# Altium schematic sheet inputbout.SchDoc
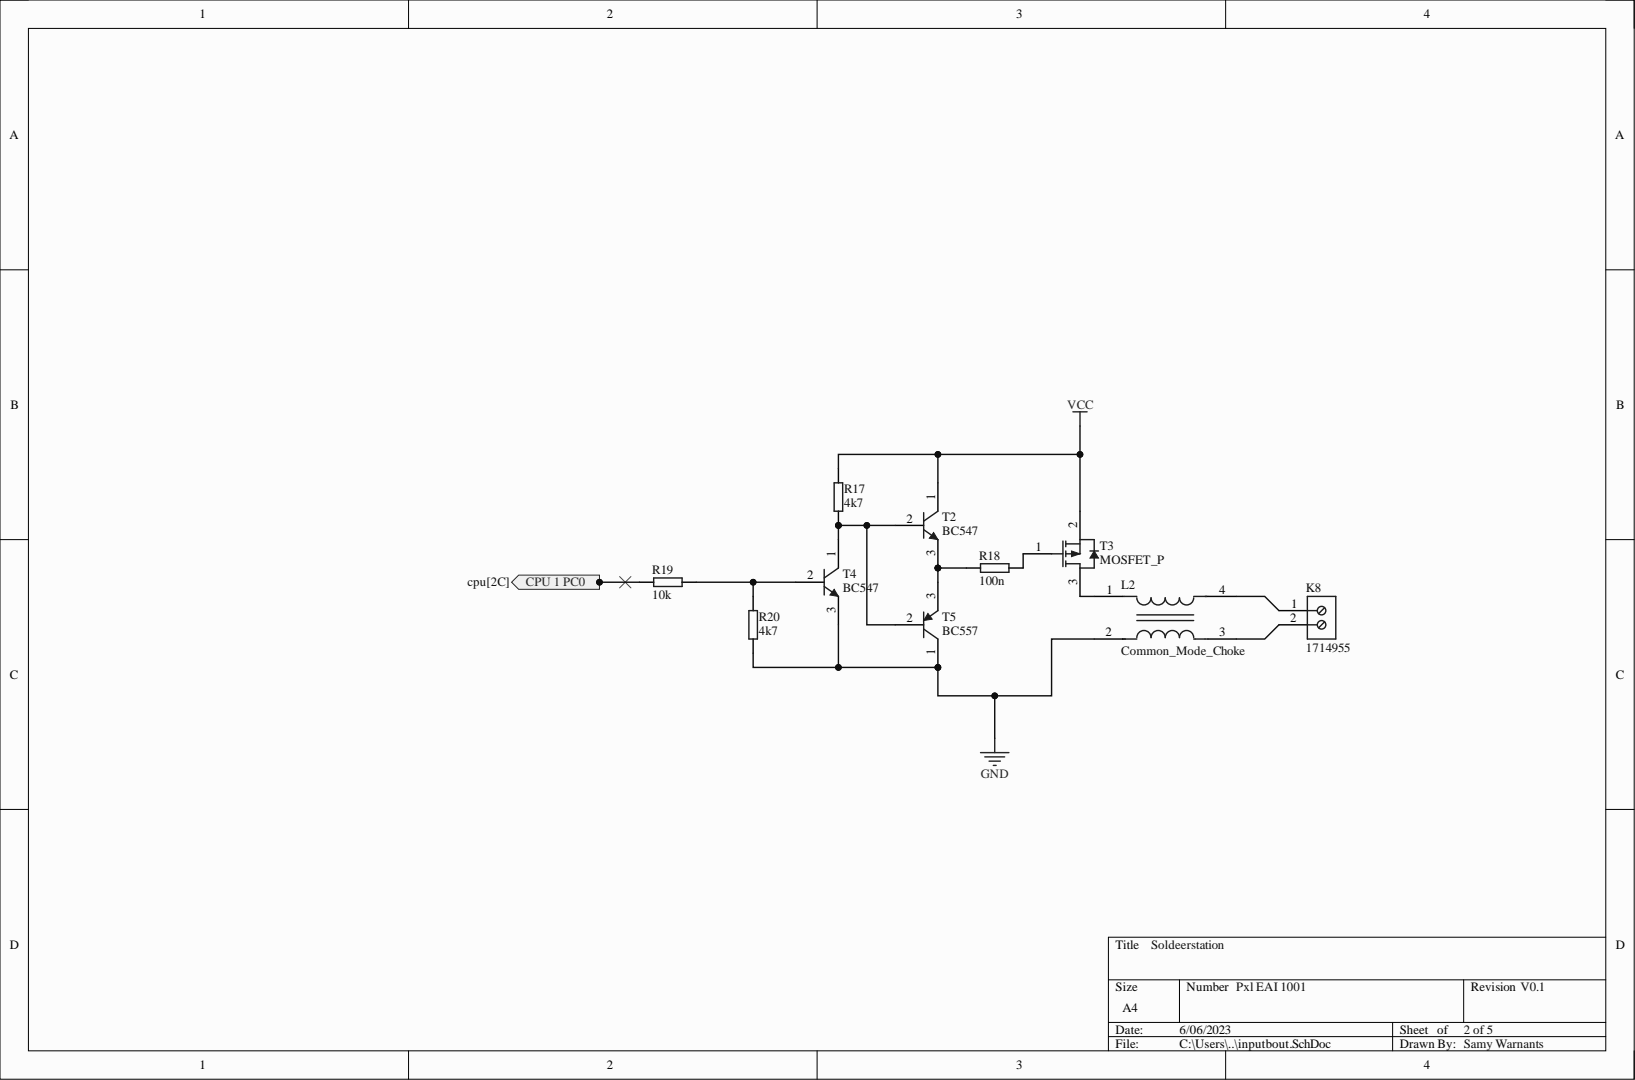
[diagram p0: inputbout.SchDoc]

## Parts
K8  1714955  [PHOE-1714955_V]
L2  Common_Mode_Choke  [2545]
R17  4k7  [R_0805_M]
R18  100n  [R_0805_M]
R19  10k  [R_0805_M]
R20  4k7  [R_0805_M]
T2  BC547  [SOT_23]
T3  MOSFET_P  [TO_252]
T4  BC547  [SOT_23]
T5  BC557  [SOT_23]

## Ports
CPU 1 PC0

## Nets
GND: L2.2 R20.1 T4.3 T5.1
VCC: R17.2 T2.1 T3.2
CPU 1 PC0: R19.2 ; port CPU 1 PC0
NetK8_1: K8.1 L2.4
NetK8_2: K8.2 L2.3
NetL2_1: L2.1 T3.3
NetR17_1: R17.1 T2.2 T4.1 T5.2
NetR18_1: R18.1 T3.1
NetR18_2: R18.2 T2.3 T5.3
NetR19_1: R19.1 R20.2 T4.2

## Library data of the parts
K8 = CMP-2000-05797-1: PC Terminal Block, Pitch 6.35 mm, 1 x 2 Position, Height 21.5 mm, Tail Lenght 5.1 mm, RoHS, Bulk; Manufacturer: Phoenix Contact; Component Kind: Standard; Datasheet URL: http://media.digikey.com/pdf/Data%20Sheets/Phoenix%20Contact%20PDFs/1714955.pdf; Datasheet Version: 02/2010; Library Name: Altium Content Vault; Manufacturer URL: http://www.phoenixcontact.com/; Mounting Technology: ThroughHole; Package Description: PC Terminal Block, Pitch 6.35 mm, 1 x 2 Position, Height 21.5 mm, Tail Lenght 5.1 mm; Package Reference: 1714955
L2 = Common_Mode_Choke: Current: 2A; Current Rating: 2.3A; DC Resistance (DCR): 60mR; Element Configuration: Dual; Impedance: 900R; Insulation Resistance: 10MR; Max Voltage Rating (DC): 50V; Number of Lines: 2; Test Frequency: 100MHz; Case/Package: 1808; Component Kind: Standard; DC Current: 2A; DC Resistance-Max: 60mR; Depth: 4.7mm; Height: 2mm; Lead Free: Lead Free; Length: 0.185inch; Max Current Rating: 2A; Max DC Current: 2A; Max Operating Temperature: 85°C; Min Operating Temperature: -40°C; Mount: Surface Mount; Number of Pins: 4; Package Quantity: 1; Packaging: Tape and Reel; Radiation Hardening: No; REACH SVHC: No SVHC; RoHS Compliant: Yes; Series: ACM; Termination: Flat; Voltage Rating: 50V; Voltage Rating (DC): 50V; Width: 4.5mm; Library Name: Soldeerstation_Final (1).SchLib
R17-R20 = Resistor: Manufacturer: Vishay Dale; Part Number: CRCW08050000Z0EA; Component Kind: Standard; Library Name: Soldeerstation_Final (1).SchLib
T2 T4 = Transistor_NPN: Manufacturer: On Semiconductor; PartNumber: BC547C; fT Min (MHz): 150; IC Continuous (A): 0.1; Packing: Bulk Box; Polarity: NPN; Component Kind: Standard; ComponentLink1Description: Manufacturer URL; ComponentLink1URL: http://www.onsemi.com/; ComponentLink2Description: Datasheet; ComponentLink2URL: http://www.onsemi.com/pub_link/Collateral/BC546-D.PDF; ComponentLink3Description: Package Specification; ComponentLink3URL: http://www.onsemi.cn/pub_link/Collateral/2N6426-D.PDF; DatasheetVersion: Rev. 7; Mounting Technology: Through Hole; PackageDescription: 3-Pin Transistor Outline, Body 4.825 x 3.685 mm, Pitch 1.27 mm; PackageReference: TO-92-3-29-11_AM_SL; PackageVersion: Rev. AM; RoHS: FALSE; Library Name: Soldeerstation_Final (1).SchLib
T3 = MOSFET_P: Manufacturer: Fairchild Semiconductor; Continuous Drain Current Id: 2.4A; Current Temperature: 25°C; Drain Source Voltage Vds: 20V; External Depth: 2.5mm; External Length / Height: 1.12mm; External Width: 3.05mm; No. of Pins: 3; On Resistance Rds(on): 52mohm; Package Version: Rev. 3, 05/2015; Power Dissipation Pd: 500mW; Pulse Current Idm: 10A; Rds(on) Test Voltage Vgs: -4.5V; Reel Quantity: 3000; SMD Marking: 304P; SVHC: NoSVHC(19-Dec-2012); Threshold Voltage Vgs Typ: -800mV; Transistor Case Style: SOT-23; Transistor Polarity: PChannel; Voltage Vds Typ: -20V; Voltage Vgs th Max: -1.5V; Component Kind: Standard; Current Id Max: 2.4A; Datasheet URL: https://www.fairchildsemi.com/datasheets/FD/FDN304P.pdf; Datasheet Version: Rev. C, 01/2001; Full Power Rating Temperature: 25°C; Manufacturer URL: https://www.fairchildsemi.com/; Mounting Technology: SurfaceMount; Operating Temperature Max: 150°C; Operating Temperature Min: -55°C; Operating Temperature Range: -55°Cto+150°C; Package / Case: SOT-23; Package Description: 3-Pin Small Outline Transistor, Body 2.92 x 1.4 mm, Pitch 0.95 mm; Package Reference: SSOT3L; Package URL: https://www.fairchildsemi.com/package-drawings/MA/MA03B.pdf; Tape Width: 8mm; Termination Type: SMD; Voltage Vds: 20V; Voltage Vgs Max: -800mV; Voltage Vgs Rds on Measurement: -4.5V; Library Name: Soldeerstation_Final (1).SchLib
T5 = Transistor_PNP: Manufacturer: On Semiconductor; PartNumber: BC557C; IC Continuous (A): -0.1; Packing: Bulk Box; Polarity: PNP; Component Kind: Standard; ComponentLink1Description: Manufacturer URL; ComponentLink1URL: http://www.onsemi.com/; ComponentLink2Description: Datasheet; ComponentLink2URL: http://www.onsemi.com/pub_link/Collateral/BC556B-D.PDF; ComponentLink3Description: Package Specification; ComponentLink3URL: http://www.onsemi.cn/pub_link/Collateral/2N6426-D.PDF; DatasheetVersion: Rev. 3; Mounting Technology: Through Hole; PackageDescription: 3-Pin Transistor Outline, Body 4.825 x 3.685 mm, Pitch 1.27 mm; PackageReference: TO-92-3-29-11_AM_SL; PackageVersion: Rev. AM; RoHS: FALSE; Library Name: Soldeerstation_Final (1).SchLib
